# Altium schematic sheet SATA-Modular-Backplane.SchDoc
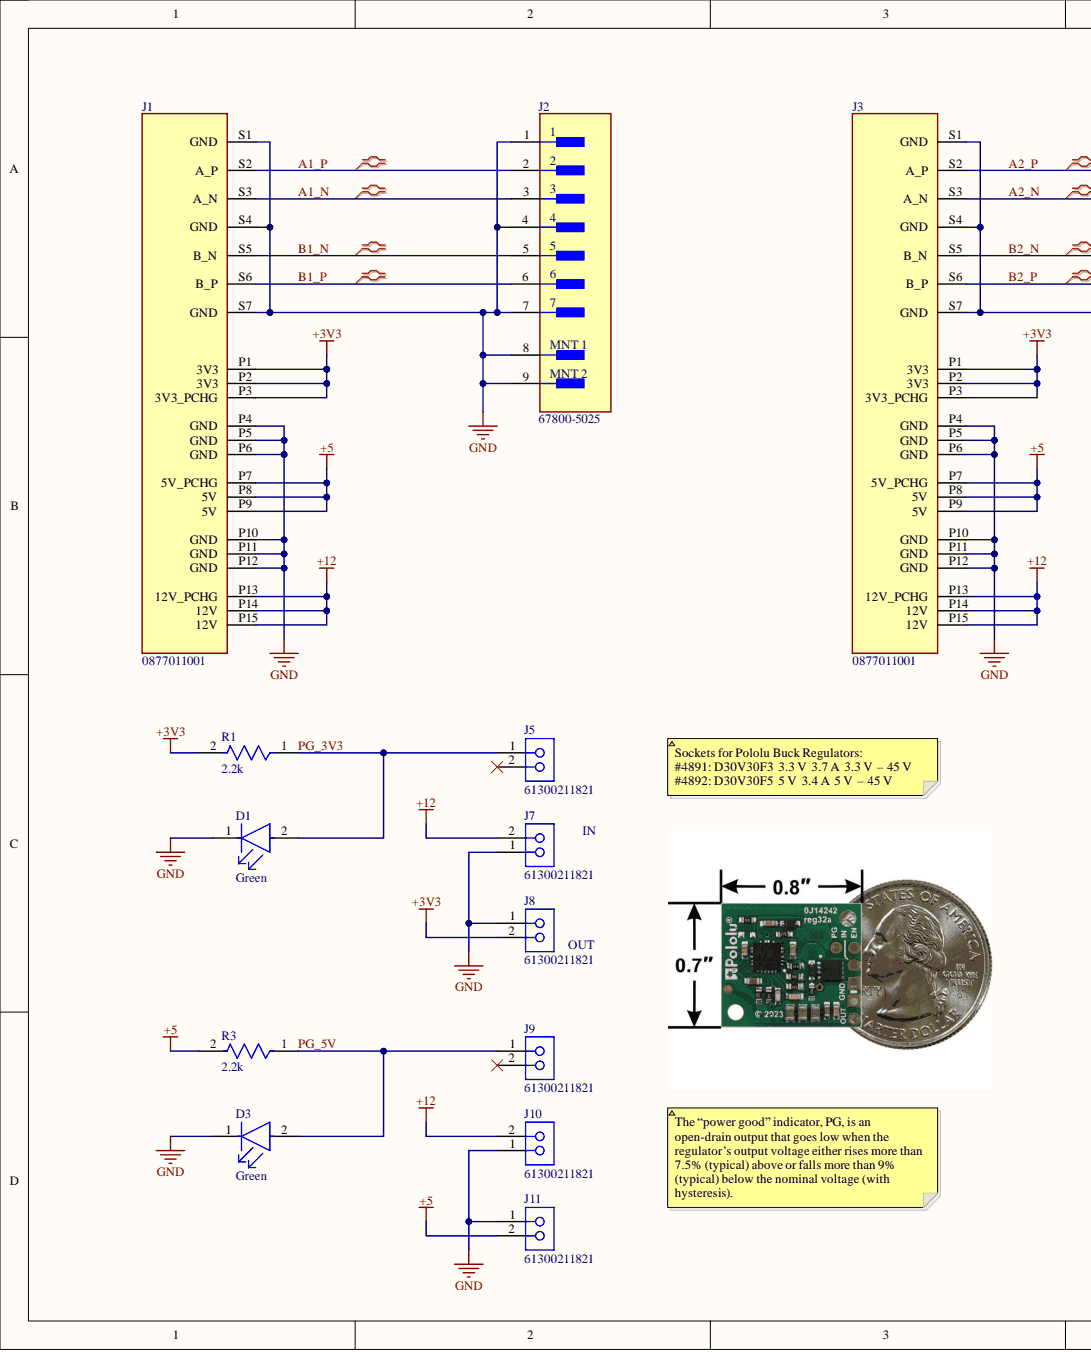
[diagram p0: SATA-Modular-Backplane.SchDoc, left]
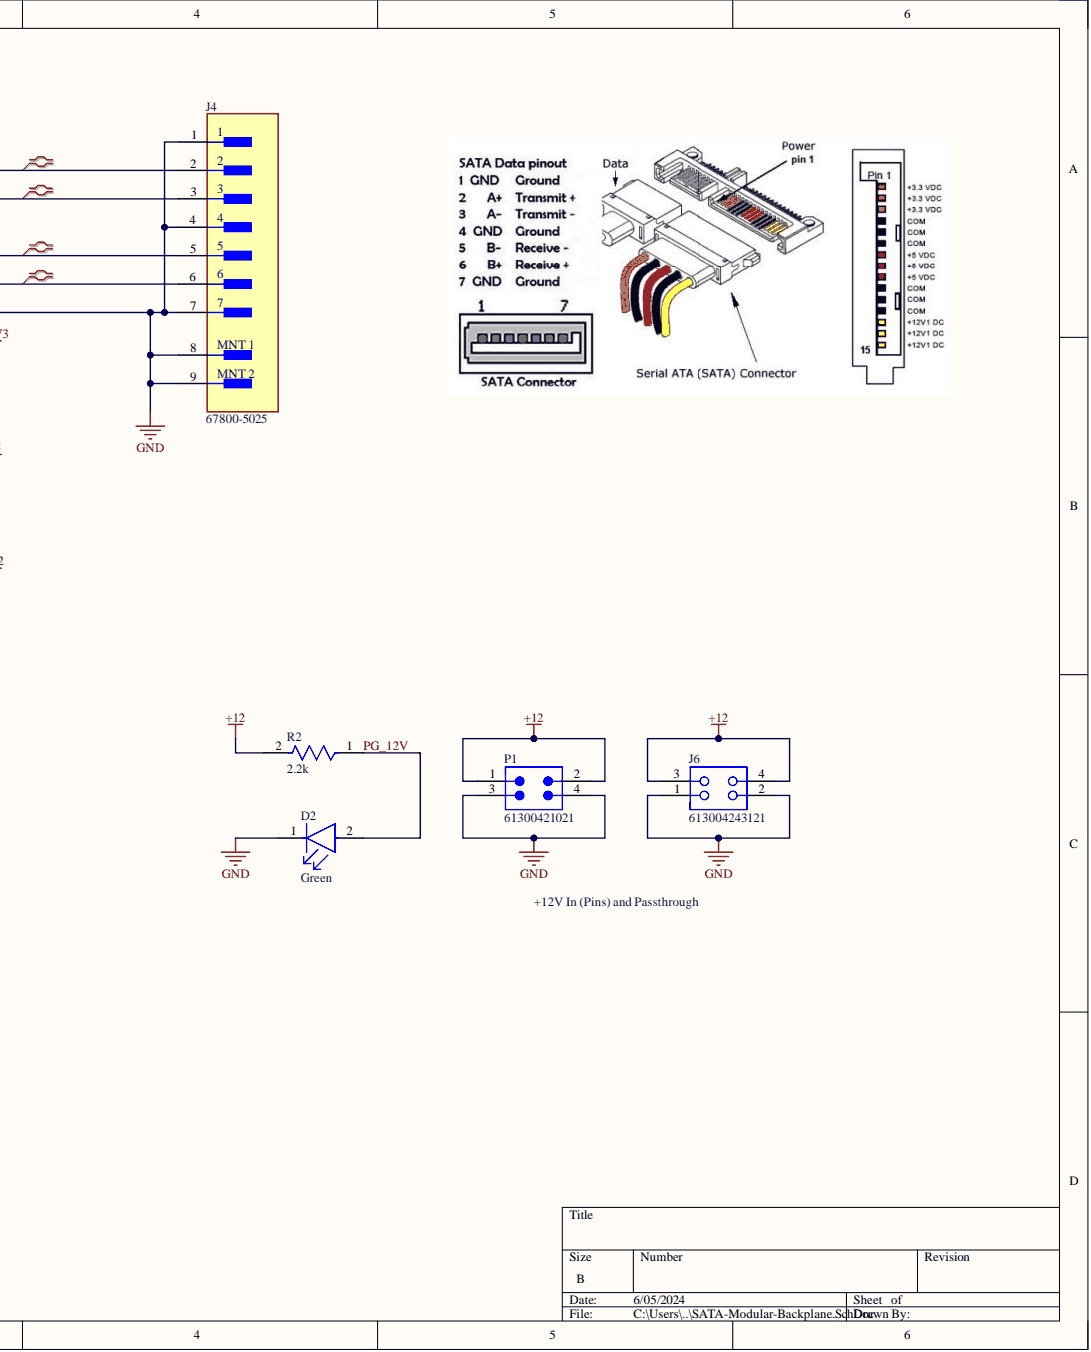
[diagram p1: SATA-Modular-Backplane.SchDoc, right]

## Parts
D1  Green  [FP-LTST-C170GKT-MFG]
D2  Green  [FP-LTST-C170GKT-MFG]
D3  Green  [FP-LTST-C170GKT-MFG]
J1  0877011001  [0877011001]
J2  67800-5025  [FP-67800-5025-MFG]
J3  0877011001  [0877011001]
J4  67800-5025  [FP-67800-5025-MFG]
J5  61300211821  [61300211821]
J6  613004243121  [613004243121]
J7  61300211821  [61300211821]
J8  61300211821  [61300211821]
J9  61300211821  [61300211821]
J10  61300211821  [61300211821]
J11  61300211821  [61300211821]
P1  61300421021  [61300421021]
R1  2.2k  [FP-CRCW0805-e3-IPC_B]
R2  2.2k  [FP-CRCW0805-e3-IPC_B]
R3  2.2k  [FP-CRCW0805-e3-IPC_B]

## Nets
+3V3: J1.P1(3V3) J1.P2(3V3) J1.P3(3V3_PCHG) J3.P1(3V3) J3.P2(3V3) J3.P3(3V3_PCHG) J8.2 R1.2
+5: J1.P7(5V_PCHG) J1.P8(5V) J1.P9(5V) J3.P7(5V_PCHG) J3.P8(5V) J3.P9(5V) J11.2 R3.2
+12: J1.P13(12V_PCHG) J1.P14(12V) J1.P15(12V) J3.P13(12V_PCHG) J3.P14(12V) J3.P15(12V) J6.3 J6.4 J7.2 J10.2 P1.1 P1.2 R2.2
GND: D1.1 D2.1 D3.1 J1.P4(GND) J1.P5(GND) J1.P6(GND) J1.P10(GND) J1.P11(GND) J1.P12(GND) J1.S1(GND) J1.S4(GND) J1.S7(GND) J2.1 J2.4 J2.7 J2.8 J2.9 J3.P4(GND) J3.P5(GND) J3.P6(GND) J3.P10(GND) J3.P11(GND) J3.P12(GND) J3.S1(GND) J3.S4(GND) J3.S7(GND) J4.1 J4.4 J4.7 J4.8 J4.9 J6.1 J6.2 J7.1 J8.1 J10.1 J11.1 P1.3 P1.4
A1_N: J1.S3(A_N) J2.3
A1_P: J1.S2(A_P) J2.2
A2_N: J3.S3(A_N) J4.3
A2_P: J3.S2(A_P) J4.2
B1_N: J1.S5(B_N) J2.5
B1_P: J1.S6(B_P) J2.6
B2_N: J3.S5(B_N) J4.5
B2_P: J3.S6(B_P) J4.6
PG_3V3: D1.2 J5.1 R1.1
PG_5V: D3.2 J9.1 R3.1
PG_12V: D2.2 R2.1

Not connected: J5 2; J9 2

## Library data of the parts
D1-D3 = CMP-1674-00002-6: LED GREEN CLEAR SMD; Dominant Wavelength: 569nm; Forward Current: 30mA; Forward Voltage: 2.1V; Lens Color: Colorless; Lens Style: Diffused; Luminous Intensity: 6mcd; Number of Elements: 1; Power Dissipation: 100mW; Reverse Voltage: 5V; Test Current: 10mA; Viewing Angle: 130°; Wavelength: 565nm; Case Code (Imperial): 0805; Case Code (Metric): 2012; Case/Package: 0805; Color: Green; Component Kind: Standard; Depth: 1.25mm; Height: 1.1mm; Illumination Color: Green; Lead Free: Lead Free; Length: 2mm; Lens Transparency: Clear; Library Name: Altium Content Vault; Max Operating Temperature: 85°C; Min Operating Temperature: -55°C; Mount: Surface Mount; Number of LEDs: 1; Number of Pins: 2; Package Quantity: 1; Packaging: Tape and Reel; Power Rating: 100mW; Radiation Hardening: No; Voltage: 5V; Width: 1.25mm
J1 J3 = 0877011001: I/O Connectors SATA COMBO HOST REC VT PFIT; Manufacturer_Name: Molex; Arrow Part Number: 0877011001; Arrow Price/Stock: https://www.arrow.com/en/products/0877011001/molex; Component Kind: Standard; Datasheet Link: https://www.molex.com/pdm_docs/sd/877011001_sd.pdf; Height: 8.25mm; Manufacturer_Part_Number: 0877011001; Mouser Part Number: N/A; Mouser Price/Stock: https://www.mouser.co.uk/ProductDetail/Molex/0877011001?qs=PBcRNPEHKsqwD0qCdO56GA%3D%3D; Library Name: Simple-Six-SATA-Backplane-Special.SchLib
J2 J4 = CMP-04776-000822-1: CONN SATA HEADER 7POS SLD SMD; Current Rating: 1.5A; Gender: Male; Glow Wire Compliant: Non-compliant; Max Voltage Rating (AC): 15V; Number of Circuits: 7; Pitch: 1.27mm; Color: Black; Component Kind: Standard; Connector Type: SATA; Contact Material: Brass; Contact Plating: Gold; Flammability Rating: UL94 V-0; Housing Material: Thermoplastic; Library Name: Altium Content Vault; Max Operating Temperature: 85°C; Min Operating Temperature: -35°C; Mount: Surface Mount; Number of Contacts: 7; Number of Positions: 7; Number of Rows: 1; Orientation: Straight; Package Quantity: 4800; Packaging: Tray; Radiation Hardening: No; Series: 67800; Termination: SMD/SMT; Voltage Rating: 15V; Voltage Rating (DC): 15V
J5 J7-J11 = CMP-1502-01314-3: NexarPartId: 61924708; Contact Resistance: 20mΩ; Current Rating: 3A; Gender: Female; Operating Supply Voltage: 250V; Component Kind: Standard; Lead Pitch: 2.54mm; Library Name: Altium Content Vault; Number of Pins: 2; Orientation: Vertical; RoHS: Non-Compliant
J6 = CMP-1502-01409-3: NexarPartId: 61924715; Contact Resistance: 20mΩ; Current Rating: 3A; Gender: Female; Operating Supply Voltage: 250V; Component Kind: Standard; Lead Pitch: 2.54mm; Library Name: Altium Content Vault; Number of Pins: 4; RoHS: Non-Compliant
P1 = CMP-1502-01065-3: NexarPartId: 32855523; Connector Type: Male Pin; Contact Resistance: 20mΩ; Current Rating: 3A; Gender: Male; Number of Contacts: 4; Operating Supply Voltage: 250V; Pitch: 2.54mm; Color: Black; Component Kind: Standard; Contact Material: Copper; Contact Mating Length: 5.9944mm; Contact Plating: Gold; Lead Pitch: 2.54mm; Library Name: Altium Content Vault; Mount: Through Hole; Number of Pins: 4; Number of Positions: 4; Number of Rows: 2; Packaging: Bulk; REACH SVHC: Unknown; RoHS: Compliant; Row Spacing: 2.54mm; Termination: Solder
R1-R3 = CMP-2001-03760-2: RES Thick Film, 2.2kΩ, 1%, 0.125W, 100ppm/°C, 0805; Max Power Dissipation: 125mW; Resistance: 2.2kR; Voltage Rating: 150V; Case Code (Imperial): 0805; Case Code (Metric): 2012; Case/Package: 0805; Component Kind: Standard; Composition: Thick Film; Contact Plating: Tin; Depth: 1.25mm; Features: Automotive AEC-Q200; Height: 0.45mm; Lead Free: Lead Free; Length: 2mm; Library Name: Altium Content Vault; Max Operating Temperature: 155°C; Military Standard: Not; Min Operating Temperature: -55°C; Number of Terminations: 2; Package Quantity: 10; Packaging: Tape and Reel; Power Rating: 125mW; Radiation Hardening: No; Temperature Coefficient: 100ppm/°C; Termination: SMD/SMT; Tolerance: 1%; Voltage Rating (DC): 150V; Weight: 0.000194oz; Width: 1.25mm
